# Altium schematic sheet MicroPET Valves.SchDoc
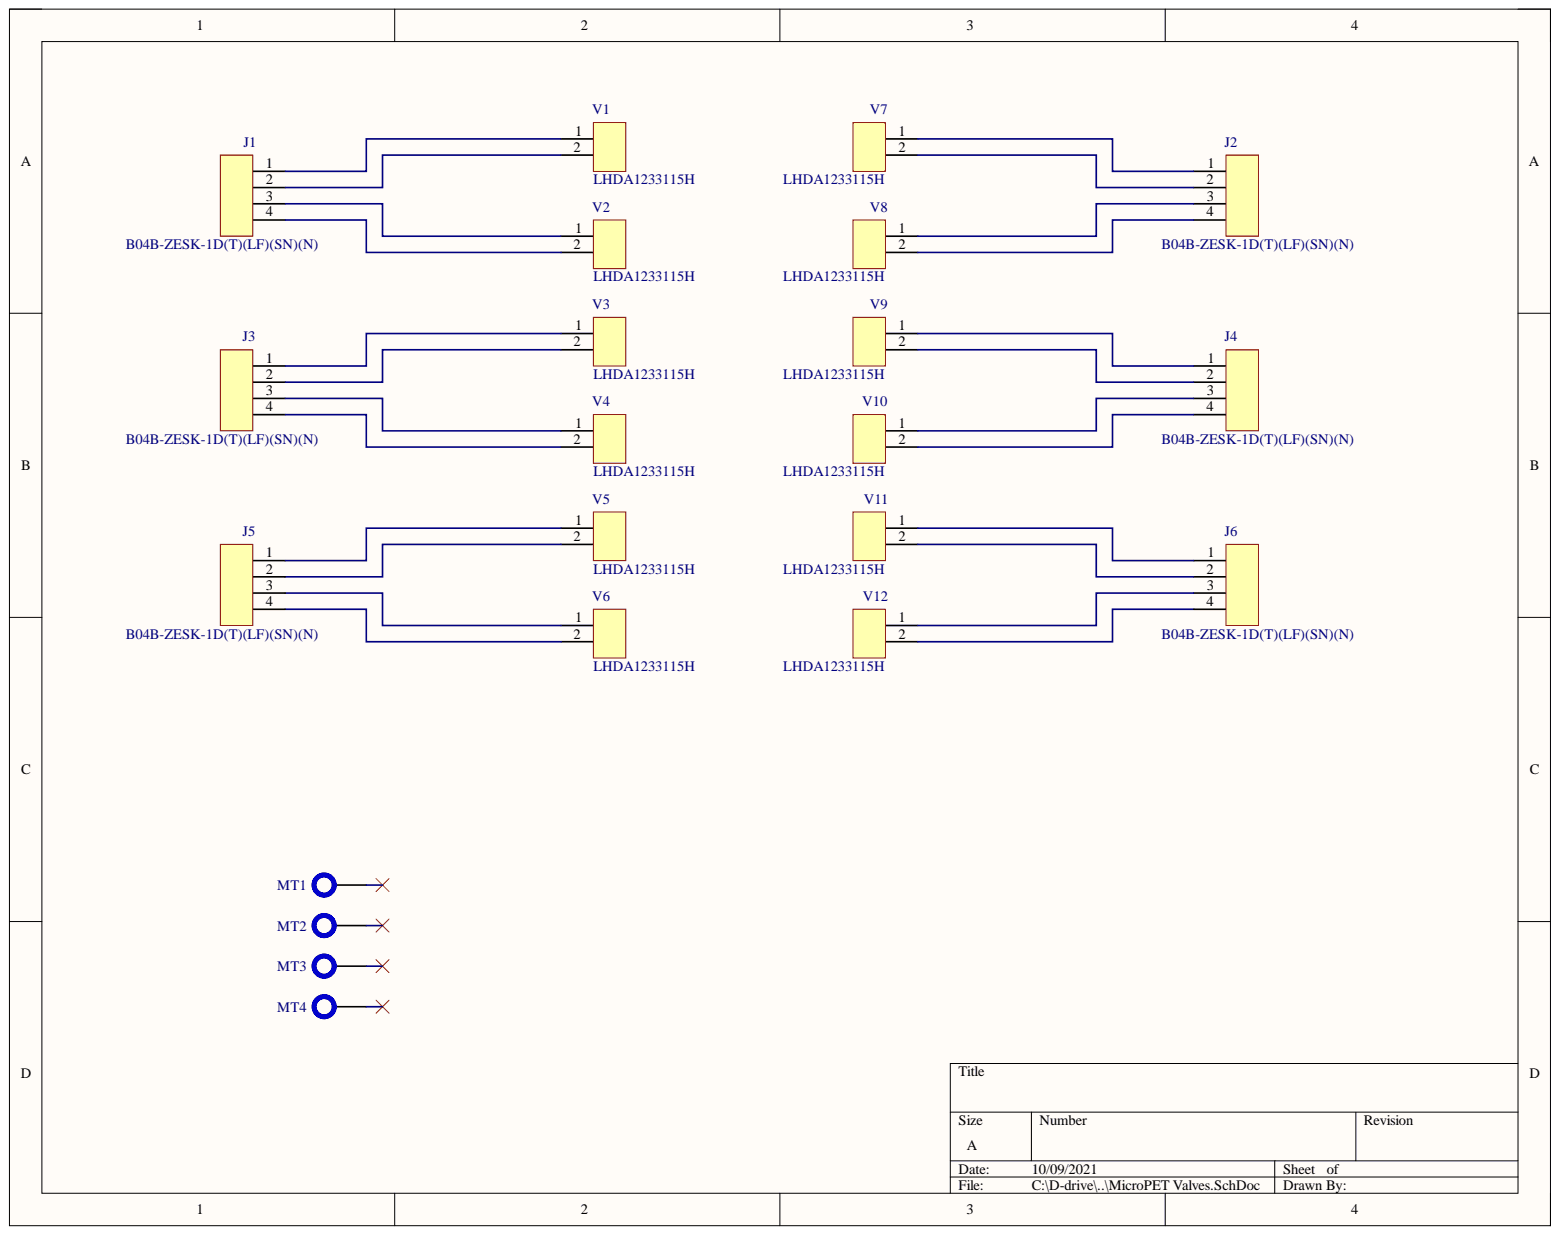
[diagram p0: MicroPET Valves.SchDoc]

## Parts
J1  B04B-ZESK-1D(T)(LF)(SN)(N)  [B04B-ZESK]
J2  B04B-ZESK-1D(T)(LF)(SN)(N)  [B04B-ZESK]
J3  B04B-ZESK-1D(T)(LF)(SN)(N)  [B04B-ZESK]
J4  B04B-ZESK-1D(T)(LF)(SN)(N)  [B04B-ZESK]
J5  B04B-ZESK-1D(T)(LF)(SN)(N)  [B04B-ZESK]
J6  B04B-ZESK-1D(T)(LF)(SN)(N)  [B04B-ZESK]
MT1  M3_MountHole  [M3_MountHole]  (value not drawn)  (no BOM)
MT2  M3_MountHole  [M3_MountHole]  (value not drawn)  (no BOM)
MT3  M3_MountHole  [M3_MountHole]  (value not drawn)  (no BOM)
MT4  M3_MountHole  [M3_MountHole]  (value not drawn)  (no BOM)
V1  LHDA1233115H  [LHDA1233115H]
V2  LHDA1233115H  [LHDA1233115H]
V3  LHDA1233115H  [LHDA1233115H]
V4  LHDA1233115H  [LHDA1233115H]
V5  LHDA1233115H  [LHDA1233115H]
V6  LHDA1233115H  [LHDA1233115H]
V7  LHDA1233115H  [LHDA1233115H]
V8  LHDA1233115H  [LHDA1233115H]
V9  LHDA1233115H  [LHDA1233115H]
V10  LHDA1233115H  [LHDA1233115H]
V11  LHDA1233115H  [LHDA1233115H]
V12  LHDA1233115H  [LHDA1233115H]

## Nets
NetJ1_1: J1.1 V1.1
NetJ1_2: J1.2 V1.2
NetJ1_3: J1.3 V2.1
NetJ1_4: J1.4 V2.2
NetJ2_1: J2.1 V7.1
NetJ2_2: J2.2 V7.2
NetJ2_3: J2.3 V8.1
NetJ2_4: J2.4 V8.2
NetJ3_1: J3.1 V3.1
NetJ3_2: J3.2 V3.2
NetJ3_3: J3.3 V4.1
NetJ3_4: J3.4 V4.2
NetJ4_1: J4.1 V9.1
NetJ4_2: J4.2 V9.2
NetJ4_3: J4.3 V10.1
NetJ4_4: J4.4 V10.2
NetJ5_1: J5.1 V5.1
NetJ5_2: J5.2 V5.2
NetJ5_3: J5.3 V6.1
NetJ5_4: J5.4 V6.2
NetJ6_1: J6.1 V11.1
NetJ6_2: J6.2 V11.2
NetJ6_3: J6.3 V12.1
NetJ6_4: J6.4 V12.2

Not connected: MT1 M1; MT2 M1; MT3 M1; MT4 M1

## Library data of the parts
J1-J6 = B04B-ZESK-1D(T)(LF)(SN)(N): CONN HEADER VERT 4POS 1.5MM; Manufacturer: JST; Connector Type: Male Pin; Database Table Name: Connectors; Gender: Male; MF: JST Sales; MP: S4B-PH-K-S_LF__SN_; Number of Contacts: 4; Pitch: 0.059inch; Category: Connectors; Color: Natural; Component Kind: Standard; ComponentLink1Description: https://datasheet.ciiva.com/742/eze-742229.pd; ComponentLink1URL: https://datasheet.ciiva.com/742/eze-742229.pd; ComponentLink2Description: https://datasheet.ciiva.com/46409/1706589-464; ComponentLink2URL: https://datasheet.ciiva.com/46409/1706589-464; Contact Material: Copper; Contact Plating: Tin; Contact Type: Male Pin; Digi-Key_Part_Number: 455-1721-ND; Digi-Key_Purchase_URL: https://www.digikey.com/product-detail/en/jst-sales-america-inc/S4B-PH-K-S_LF__SN_/455-1721-ND/926628?utm_source=snapeda&utm_medium=aggregator&utm_campaign=symbol; Library Name: AltiumDBLib.DbLib; Manufacturer Part Number: B04B-ZESK-1D(T)(LF)(SN)(N); Mount: Through Hole; Number of Positions: 4; Number of Rows: 1; Package: None; Package Quantity: 1664; Packaging: Tray; Part Name: B04B-ZESK-1D(T)(LF)(SN)(N); Pricing 1: 1=0.56000, 10=0.52800, 80=0.40450, 440=0.3518; REACH SVHC: Unknown; RoHS: Yes; Series: ZE; SnapEDA_Link: https://www.snapeda.com/parts/S4B-PH-K-S%28LF%29%28SN%29/JST%20Sales%20America%20Inc./view-part/?ref=snap; Stock 1: 793; Supplier 1: Digi-Key; Supplier Part Number 1: 455-2665-ND; Termination: Solder
MT1-MT4 = M3_MountHole: M3 Mounting Hole; Database Table Name: Misc.; Library Name: AltiumDBLib.DbLib; Part Name: M3_MountHole
V1-V12 = LHDA1233115H: Database Table Name: Misc.; MF: JST Sales; MP: S4B-PH-K-S_LF__SN_; Component Kind: Standard; Digi-Key_Part_Number: 455-1721-ND; Digi-Key_Purchase_URL: https://www.digikey.com/product-detail/en/jst-sales-america-inc/S4B-PH-K-S_LF__SN_/455-1721-ND/926628?utm_source=snapeda&utm_medium=aggregator&utm_campaign=symbol; Library Name: AltiumDBLib.DbLib; Package: None; Part Name: LHDA1233115H; SnapEDA_Link: https://www.snapeda.com/parts/S4B-PH-K-S%28LF%29%28SN%29/JST%20Sales%20America%20Inc./view-part/?ref=snap
